# Altium schematic sheet Frequency_Analog.SchDoc
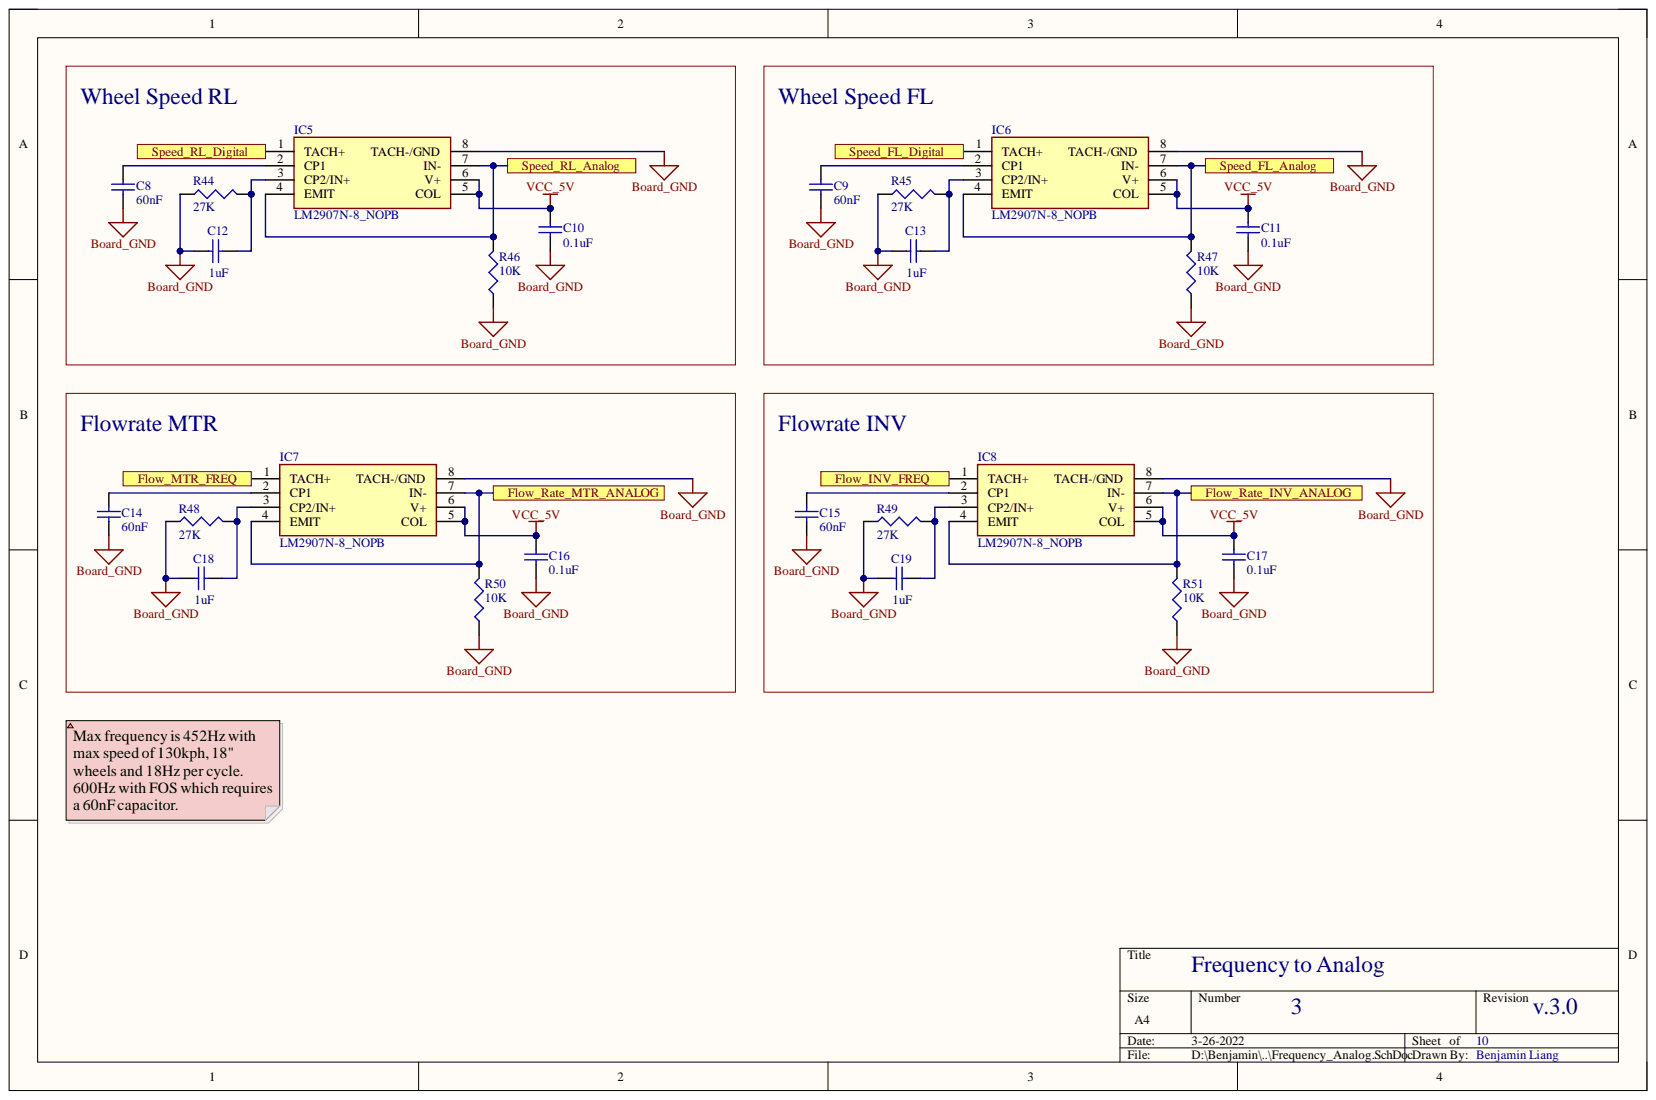
[diagram p0: Frequency_Analog.SchDoc]

## Parts
C8  60nF  [C1206]
C9  60nF  [C1206]
C10  0.1uF  [C1206]
C11  0.1uF  [C1206]
C12  1uF  [C1206]
C13  1uF  [C1206]
C14  60nF  [C1206]
C15  60nF  [C1206]
C16  0.1uF  [C1206]
C17  0.1uF  [C1206]
C18  1uF  [C1206]
C19  1uF  [C1206]
IC5  LM2907N-8_NOPB  [DIP794W53P254L959H508Q8N]
IC6  LM2907N-8_NOPB  [DIP794W53P254L959H508Q8N]
IC7  LM2907N-8_NOPB  [DIP794W53P254L959H508Q8N]
IC8  LM2907N-8_NOPB  [DIP794W53P254L959H508Q8N]
R44  27K  [J1-0603]
R45  27K  [J1-0603]
R46  10K  [J1-0603]
R47  10K  [J1-0603]
R48  27K  [J1-0603]
R49  27K  [J1-0603]
R50  10K  [J1-0603]
R51  10K  [J1-0603]

## Ports
Flow_INV_FREQ, Flow_MTR_FREQ, Flow_Rate_INV_ANALOG, Flow_Rate_MTR_ANALOG, Speed_FL_Analog, Speed_FL_Digital, Speed_RL_Analog, Speed_RL_Digital

## Nets
Board_GND: C8.2 C9.2 C10.2 C11.2 C12.2 C13.2 C14.2 C15.2 C16.2 C17.2 C18.2 C19.2 IC5.8(TACH-/GND) IC6.8(TACH-/GND) IC7.8(TACH-/GND) IC8.8(TACH-/GND) R44.1 R45.1 R46.1 R47.1 R48.1 R49.1 R50.1 R51.1
VCC_5V: C10.1 C11.1 C16.1 C17.1 IC5.5(COL) IC5.6(V+) IC6.5(COL) IC6.6(V+) IC7.5(COL) IC7.6(V+) IC8.5(COL) IC8.6(V+)
Flow_INV_FREQ: IC8.1(TACH+) ; port Flow_INV_FREQ
Flow_MTR_FREQ: IC7.1(TACH+) ; port Flow_MTR_FREQ
Flow_Rate_INV_ANALOG: IC8.4(EMIT) IC8.7(IN-) R51.2 ; port Flow_Rate_INV_ANALOG
Flow_Rate_MTR_ANALOG: IC7.4(EMIT) IC7.7(IN-) R50.2 ; port Flow_Rate_MTR_ANALOG
Speed_FL_Analog: IC6.4(EMIT) IC6.7(IN-) R47.2 ; port Speed_FL_Analog
Speed_FL_Digital: IC6.1(TACH+) ; port Speed_FL_Digital
Speed_RL_Analog: IC5.4(EMIT) IC5.7(IN-) R46.2 ; port Speed_RL_Analog
Speed_RL_Digital: IC5.1(TACH+) ; port Speed_RL_Digital
NetC8_1: C8.1 IC5.2(CP1)
NetC9_1: C9.1 IC6.2(CP1)
NetC12_1: C12.1 IC5.3(CP2/IN+) R44.2
NetC13_1: C13.1 IC6.3(CP2/IN+) R45.2
NetC14_1: C14.1 IC7.2(CP1)
NetC15_1: C15.1 IC8.2(CP1)
NetC18_1: C18.1 IC7.3(CP2/IN+) R48.2
NetC19_1: C19.1 IC8.3(CP2/IN+) R49.2

## Library data of the parts
C8-C19 = Cap Semi: Capacitor (Semiconductor SIM Model); Value: 100nF; Component Kind: Standard; LatestRevisionDate: 29-May-2009; LatestRevisionNote: IPC-7351 Footprint Added.; Library Name: Miscellaneous Devices.IntLib; PackageDescription: Chip Capacitor; PackageReference: C1206; Published: 8-Jun-2000; Signal Integrity: Cap Semi; Simulation: CAP; Publisher: Altium Limited
IC5-IC8 = LM2907N-8_NOPB: Integrated Circuit; Manufacturer_Name: Texas Instruments; Manufacturer_Part_Number: LM2907N-8/NOPB; Arrow Part Number: LM2907N-8/NOPB; Arrow Price/Stock: https://www.arrow.com/en/products/lm2907n-8nopb/texas-instruments?region=nac; Component Kind: Standard; Datasheet Link: http://www.ti.com/lit/gpn/lm2907-n; Height: 5.08mm; Mouser Part Number: 926-LM2907N-8/NOPB; Mouser Price/Stock: https://www.mouser.co.uk/ProductDetail/Texas-Instruments/LM2907N-8-NOPB?qs=X1J7HmVL2ZGu14rEpM%2FYHQ%3D%3D
R44 R45 R48 R49 = Res3: Resistor; Value: 10K; Component Kind: Standard; LatestRevisionDate: 29-May-2009; LatestRevisionNote: IPC-7351 Footprint Added.; Library Name: Miscellaneous Devices.IntLib; PackageDescription: Chip Resistor; PackageReference: J1-0603; Published: 8-Jun-2000; Signal Integrity: Res; Simulation: RESISTOR; Publisher: Altium Limited
R46 R47 R50 R51 = Res3: Resistor; Component Kind: Standard; LatestRevisionDate: 29-May-2009; LatestRevisionNote: IPC-7351 Footprint Added.; Library Name: Miscellaneous Devices.IntLib; PackageDescription: Chip Resistor; PackageReference: J1-0603; Published: 8-Jun-2000; Signal Integrity: Res; Simulation: RESISTOR; Value: 10K; Publisher: Altium Limited
